# Altium schematic sheet Schematic.SchDoc(Schematic)
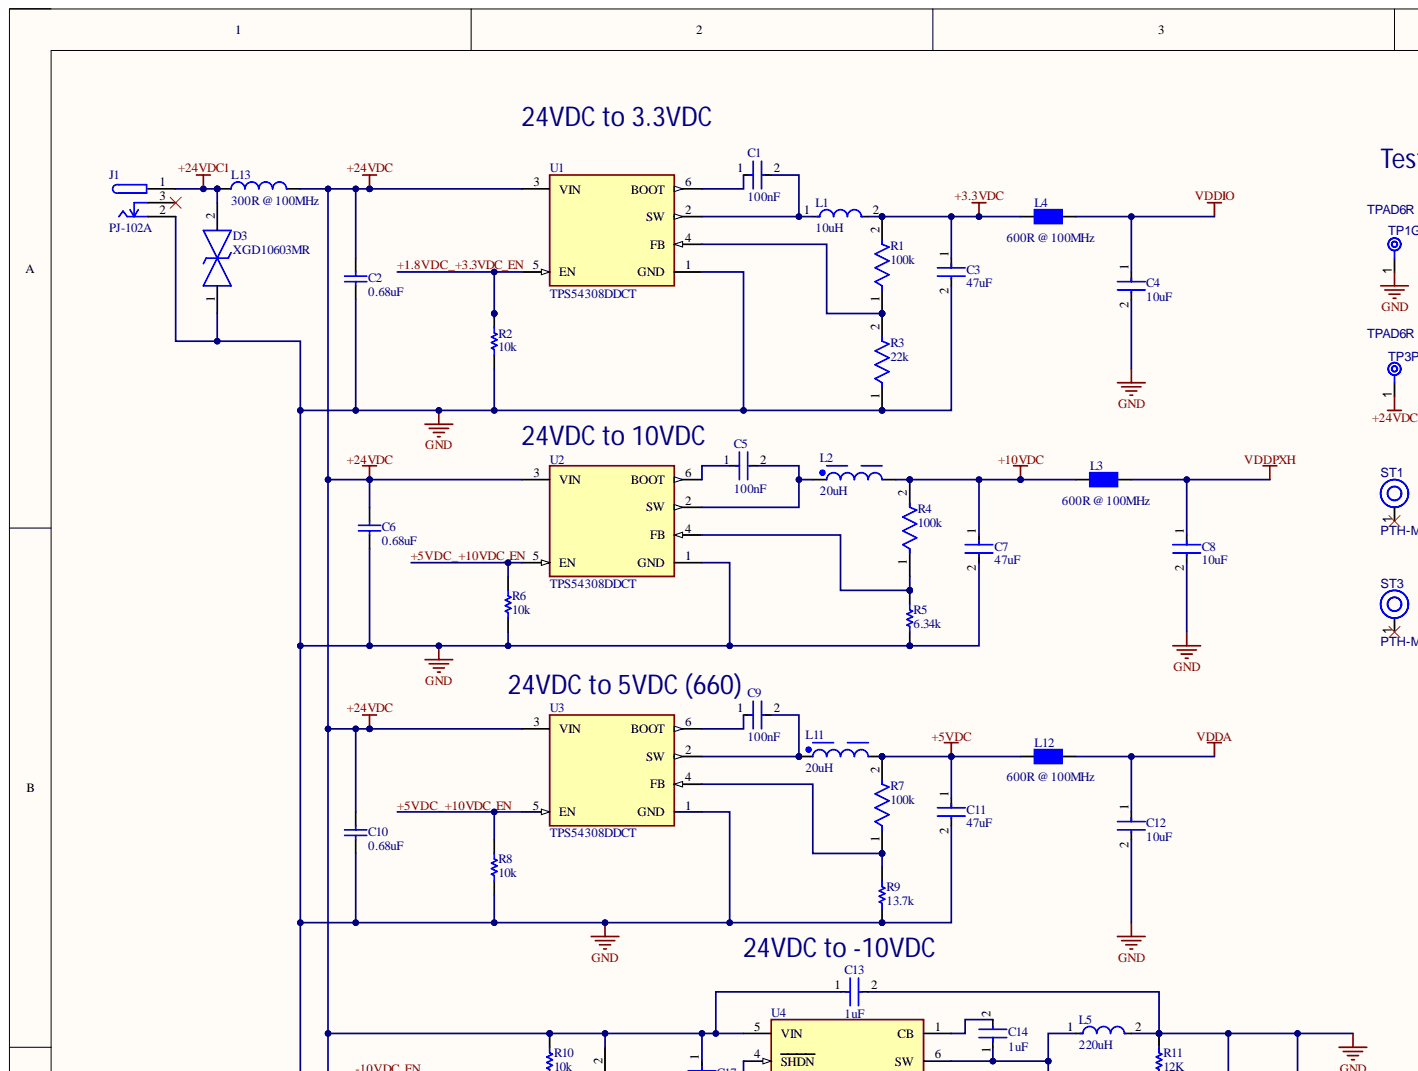
[diagram p0: Schematic.SchDoc, top left]
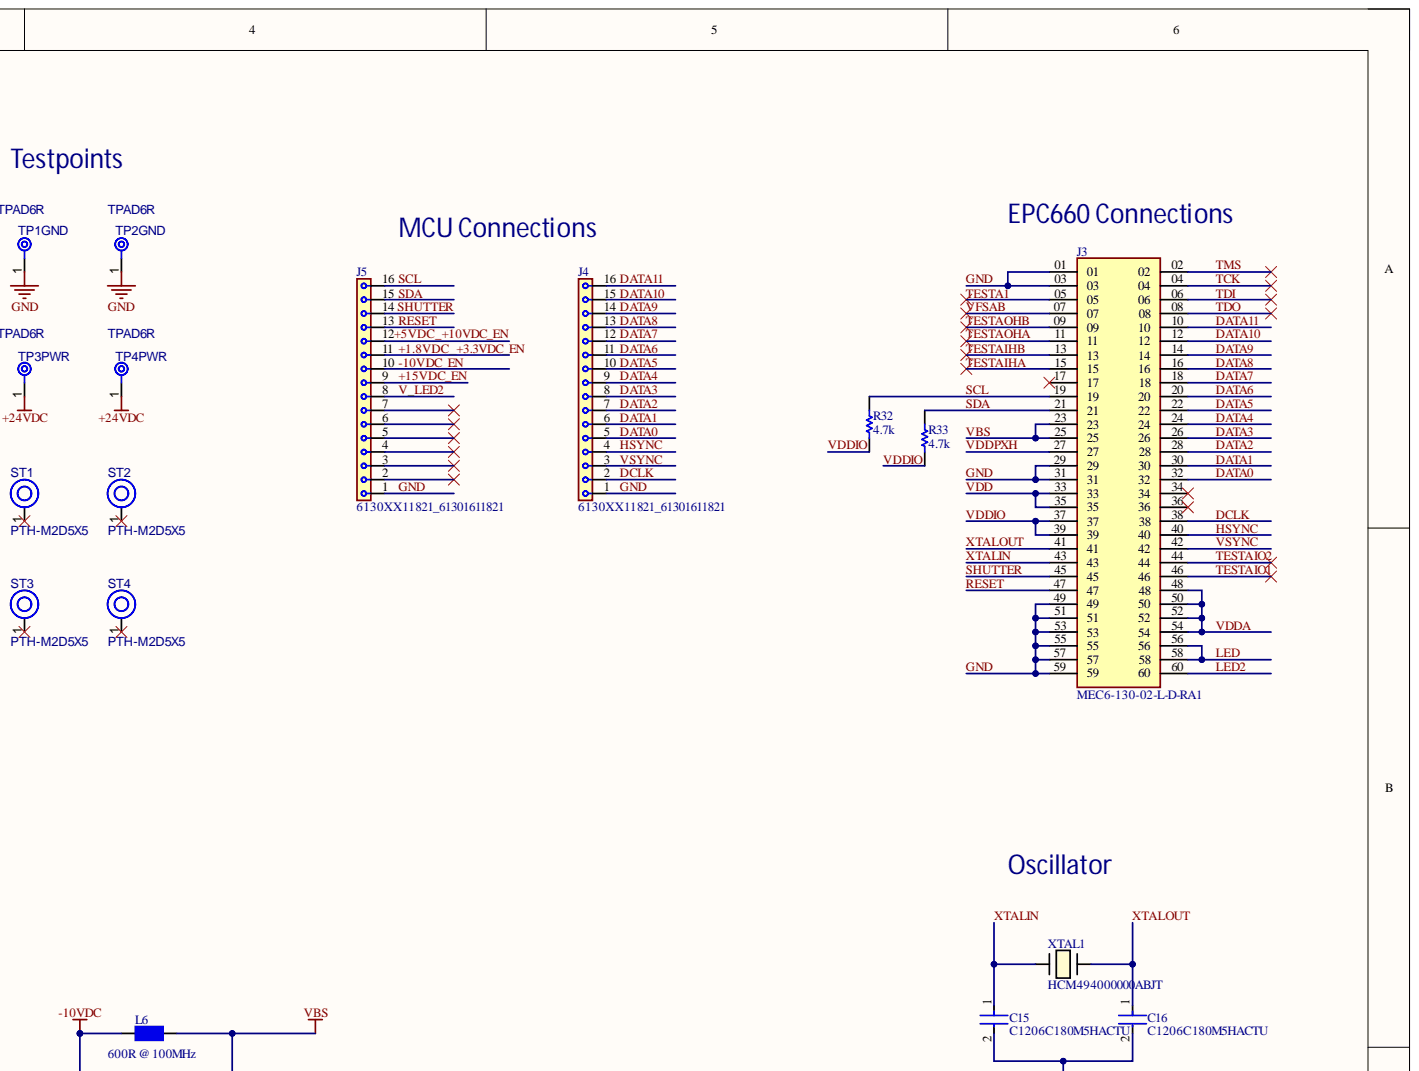
[diagram p1: Schematic.SchDoc, top right]
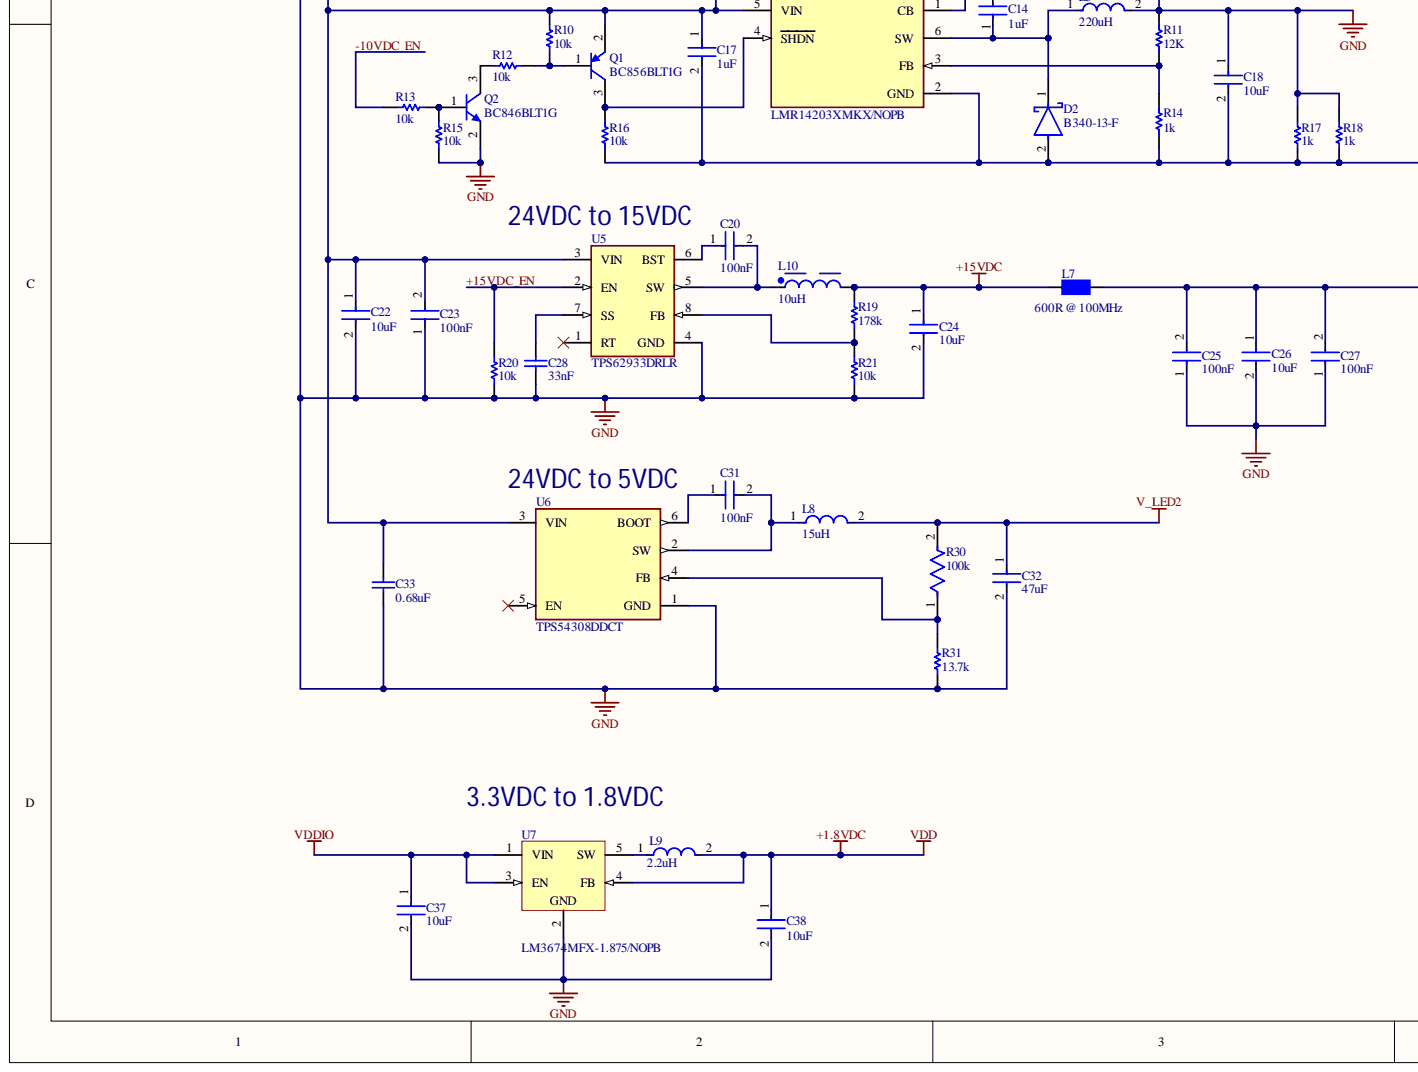
[diagram p2: Schematic.SchDoc, bottom left]
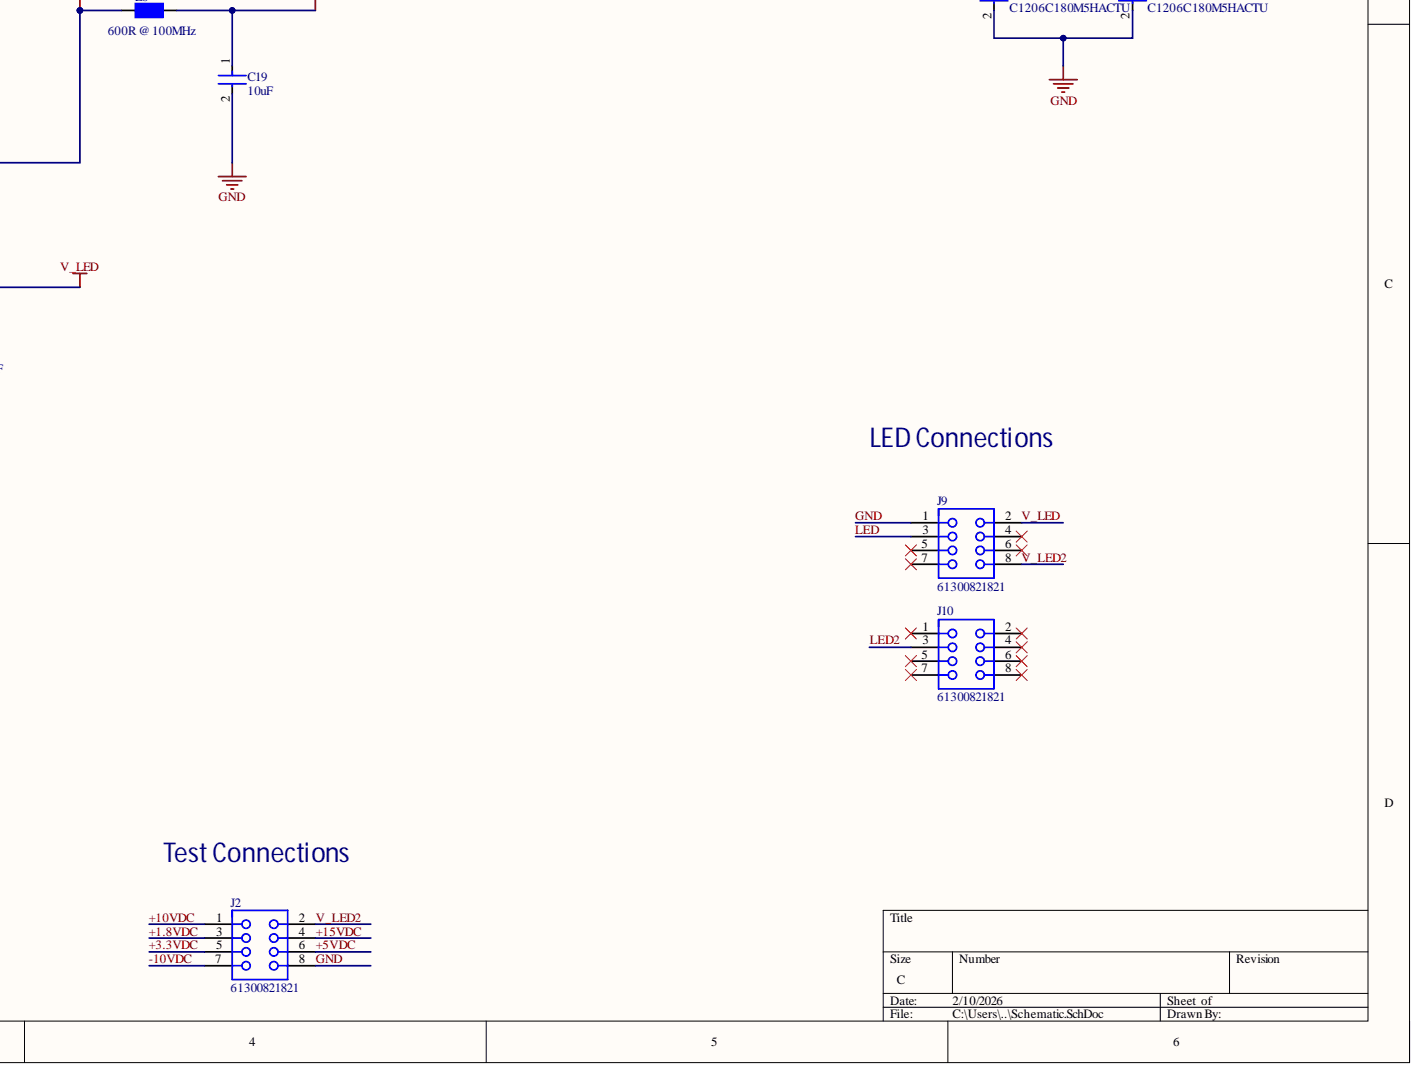
[diagram p3: Schematic.SchDoc, bottom right]

## Parts
C1  100nF  [FP-C0805C-DN-MFG]
C2  0.68uF  [CAPC2013X145X50ML20T25]
C3  47uF  [FP-C3216-160-0_2-MFG]
C4  10uF  [FP-CL31B106KBHNNNE-MFG]
C5  100nF  [FP-C0805C-DN-MFG]
C6  0.68uF  [CAPC2013X145X50ML20T25]
C7  47uF  [FP-C3216-160-0_2-MFG]
C8  10uF  [FP-CL31B106KBHNNNE-MFG]
C9  100nF  [FP-C0805C-DN-MFG]
C10  0.68uF  [CAPC2013X145X50ML20T25]
C11  47uF  [FP-C3216-160-0_2-MFG]
C12  10uF  [FP-CL31B106KBHNNNE-MFG]
C13  1uF  [FP-0805-L_2_0_0_1-W_1_25-MFG]
C14  1uF  [FP-0805-L_2_0_0_1-W_1_25-MFG]
C15  C1206C180M5HACTU  [FP-C1206C-EB-MFG]
C16  C1206C180M5HACTU  [FP-C1206C-EB-MFG]
C17  1uF  [FP-0805-L_2_0_0_1-W_1_25-MFG]
C18  10uF  [FP-CL31B106KBHNNNE-MFG]
C19  10uF  [FP-CL31B106KBHNNNE-MFG]
C20  100nF  [FP-C0805C-DN-MFG]
C22  10uF  [FP-CL31B106KBHNNNE-MFG]
C23  100nF  [FP-C0805C-DN-MFG]
C24  10uF  [FP-CL31B106KBHNNNE-MFG]
C25  100nF  [FP-C0805C-DN-MFG]
C26  10uF  [FP-CL31B106KBHNNNE-MFG]
C27  100nF  [FP-C0805C-DN-MFG]
C28  33nF  [CAPC2013X94X50NL20T25]
C31  100nF  [FP-C0805C-DN-MFG]
C32  47uF  [FP-C3216-160-0_2-MFG]
C33  0.68uF  [CAPC2013X145X50ML20T25]
C37  10uF  [FP-CL31B106KBHNNNE-MFG]
C38  10uF  [FP-CL31B106KBHNNNE-MFG]
D2  B340-13-F  [FP-SMC-MFG]
D3  XGD10603MR  [DIONC1708X51N]
J1  PJ-102A  [FP-PJ-102A-MFG]
J2  61300821821  [61300821821]
J3  MEC6-130-02-L-D-RA1  [SAMTEC_MEC6-130-02-L-D-RA1]
J4  6130XX11821_61301611821  [61301611821]
J5  6130XX11821_61301611821  [61301611821]
J9  61300821821  [61300821821]
J10  61300821821  [61300821821]
L1  10uH  [FP-LB3225-2_5-0_2-IPC_B]
L2  20uH  [WE-TI_8095]
L3  600R @ 100MHz  [BEADC2012X105N]
L4  600R @ 100MHz  [BEADC2012X105N]
L5  220uH  [FP-NR10050-MFG]
L6  600R @ 100MHz  [BEADC2012X105N]
L7  600R @ 100MHz  [BEADC2012X105N]
L8  15uH  [FP-CB3225-IPC_C]
L9  2.2uH  [FP-LQM2HPN_G0-IPC_C]
L10  10uH  [IND_SRR1208-100ML]
L11  20uH  [WE-TI_8095]
L12  600R @ 100MHz  [BEADC2012X105N]
L13  300R @ 100MHz  [WE-CBF_0805_L2W1.2H0.9_HC]
Q1  BC856BLT1G  [ONSC-SOT-23-3-318-08_V]
Q2  BC846BLT1G  [ONSC-SOT-23-3-318-08_V]
R1  100k  [FP-RC0805-0_6-IPC_C]
R2  10k  [RESC2013X60X35ML10T20]
R3  22k  [FP-RC0805-0_6-IPC_A]
R4  100k  [FP-RC0805-0_6-IPC_C]
R5  6.34k  [RESC2013X60X35LL10T20]
R6  10k  [RESC2013X60X35ML10T20]
R7  100k  [FP-RC0805-0_6-IPC_C]
R8  10k  [RESC2013X60X35ML10T20]
R9  13.7k  [RESC3116X65X40NL10T20]
R10  10k  [RESC2013X60X35ML10T20]
R11  12K  [RESC0805(2012)_L]
R12  10k  [RESC2013X60X35ML10T20]
R13  10k  [RESC2013X60X35ML10T20]
R14  1k  [RESC2013X50X30NL20T20]
R15  10k  [RESC2013X60X35ML10T20]
R16  10k  [RESC2013X60X35ML10T20]
R17  1k  [RESC2013X50X30NL20T20]
R18  1k  [RESC2013X50X30NL20T20]
R19  178k  [RESC2013X60X35LL10T20]
R20  10k  [RESC2013X60X35ML10T20]
R21  10k  [RESC2013X60X35ML10T20]
R30  100k  [FP-RC0805-0_6-IPC_C]
R31  13.7k  [RESC3116X65X40NL10T20]
R32  4.7k  [YAGO-RC0805_M]
R33  4.7k  [YAGO-RC0805_M]
ST1  PTH-M2D5X5  [PTH-M2D5X5]  (no BOM)
ST2  PTH-M2D5X5  [PTH-M2D5X5]  (no BOM)
ST3  PTH-M2D5X5  [PTH-M2D5X5]  (no BOM)
ST4  PTH-M2D5X5  [PTH-M2D5X5]  (no BOM)
TP1GND  TPAD6R  [TP06R]  (no BOM)
TP2GND  TPAD6R  [TP06R]  (no BOM)
TP3PWR  TPAD6R  [TP06R]  (no BOM)
TP4PWR  TPAD6R  [TP06R]  (no BOM)
U1  TPS54308DDCT  [FP-DDC0006A-IPC_A]
U2  TPS54308DDCT  [FP-DDC0006A-IPC_A]
U3  TPS54308DDCT  [FP-DDC0006A-IPC_A]
U4  LMR14203XMKX/NOPB  [FP-DDC0006A-IPC_B]
U5  TPS62933DRLR  [SOTFL50P160X60-8N]
U6  TPS54308DDCT  [FP-DDC0006A-IPC_A]
U7  LM3674MFX-1.875/NOPB  [MF05A_M]
XTAL1  HCM494000000ABJT  [XTAL_HCM494_CIT]

## Nets
+24VDC: C2.2 C6.2 C10.2 C13.1 C17.1 C22.1 C23.2 C33.2 L13.2 Q1.2 R10.2 TP3PWR.1 TP4PWR.1 U1.3(VIN) U2.3(VIN) U3.3(VIN) U4.5(VIN) U5.3(VIN) U6.3(VIN)
+24VDC1: D3.2 J1.1 L13.1
+1.8VDC: C38.1 J2.3 J3.33 J3.35 L9.2 U7.4(FB)
+1.8VDC_+3.3VDC_EN: J5.11 R2.2 U1.5(EN)
+3.3VDC: C3.1 J2.5 L1.2 L4.1 R1.2
+5VDC: C11.1 J2.6 L11.2 L12.1 R7.2
+5VDC_+10VDC_EN: J5.12 R6.2 R8.2 U2.5(EN) U3.5(EN)
+10VDC: C7.1 J2.1 L2.2 L3.1 R4.2
+15VDC: C24.1 J2.4 L7.1 L10.2 R19.2
+15VDC_EN: J5.9 R20.2 U5.2(EN)
-10VDC: C17.2 C18.2 D2.2 J2.7 L6.1 R14.1 R16.1 R17.1 R18.1 U4.2(GND)
-10VDC_EN: J5.10 R13.2
DATA0: J3.32 J4.5
DATA1: J3.30 J4.6
DATA2: J3.28 J4.7
DATA3: J3.26 J4.8
DATA4: J3.24 J4.9
DATA5: J3.22 J4.10
DATA6: J3.20 J4.11
DATA7: J3.18 J4.12
DATA8: J3.16 J4.13
DATA9: J3.14 J4.14
DATA10: J3.12 J4.15
DATA11: J3.10 J4.16
DCLK: J3.38 J4.2
GND: C2.1 C3.2 C4.2 C6.1 C7.2 C8.2 C10.1 C11.2 C12.2 C13.2 C15.2 C16.2 C18.1 C19.2 C22.2 C23.1 C24.2 C25.1 C26.2 C27.1 C28.1 C32.2 C33.1 C37.2 C38.2 D3.1 J1.2 J2.8 J3.01 J3.03 J3.29 J3.31 J3.49 J3.51 J3.53 J3.55 J3.57 J3.59 J4.1 J5.1 J9.1 L5.2 Q2.2 R2.1 R3.1 R5.1 R6.1 R8.1 R9.2 R11.2 R15.1 R17.2 R18.2 R20.1 R21.1 R31.2 TP1GND.1 TP2GND.1 U1.1(GND) U2.1(GND) U3.1(GND) U5.4(GND) U6.1(GND) U7.2
HSYNC: J3.40 J4.4
LED: J3.56 J3.58 J9.3
LED2: J3.60 J10.3
RESET: J3.47 J5.13
SCL: J3.19 J5.16 R32.2
SDA: J3.21 J5.15 R33.2
SHUTTER: J3.45 J5.14
TCK: J3.04
TDI: J3.06
TDO: J3.08
TESTA1: J3.05
TESTAIHA: J3.15
TESTAIHB: J3.13
TESTAIO1: J3.46
TESTAIO2: J3.44
TESTAOHA: J3.11
TESTAOHB: J3.09
TMS: J3.02
V_LED: C25.2 C26.1 C27.2 J9.2 L7.2
V_LED2: C32.1 J2.2 J5.8 J9.8 L8.2 R30.2
VBS: C19.1 J3.23 J3.25 L6.2
VDDA: C12.1 J3.48 J3.50 J3.52 J3.54 L12.2
VDDIO: C4.1 C37.1 J3.37 J3.39 L4.2 R32.1 R33.1 U7.1(VIN) U7.3(EN)
VDDPXH: C8.1 J3.27 L3.2
VFSAB: J3.07
VSYNC: J3.42 J4.3
XTALIN: C15.1 J3.43 XTAL1.1
XTALOUT: C16.1 J3.41 XTAL1.2
NetC1_1: C1.1 U1.6(BOOT)
NetC1_2: C1.2 L1.1 U1.2(SW)
NetC5_1: C5.1 U2.6(BOOT)
NetC5_2: C5.2 L2.1 U2.2(SW)
NetC9_1: C9.1 U3.6(BOOT)
NetC9_2: C9.2 L11.1 U3.2(SW)
NetC14_1: C14.1 D2.1 L5.1 U4.6(SW)
NetC14_2: C14.2 U4.1(CB)
NetC20_1: C20.1 U5.6(BST)
NetC20_2: C20.2 L10.1 U5.5(SW)
NetC28_2: C28.2 U5.7(SS)
NetC31_1: C31.1 U6.6(BOOT)
NetC31_2: C31.2 L8.1 U6.2(SW)
NetL9_1: L9.1 U7.5(SW)
NetQ1_1: Q1.1 R10.1 R12.1
NetQ1_3: Q1.3 R16.2 U4.4(SHDN)
NetQ2_1: Q2.1 R13.1 R15.2
NetQ2_3: Q2.3 R12.2
NetR1_1: R1.1 R3.2 U1.4(FB)
NetR4_1: R4.1 R5.2 U2.4(FB)
NetR7_1: R7.1 R9.1 U3.4(FB)
NetR11_1: R11.1 R14.2 U4.3(FB)
NetR19_1: R19.1 R21.2 U5.8(FB)
NetR30_1: R30.1 R31.1 U6.4(FB)

Not connected: J1 3; J3 17 34 36; J5 2 3 4 5 6 7; J9 4 5 6 7; J10 1 2 4 5 6 7 8; ST1 1; ST2 1; ST3 1; ST4 1; U5 1(RT); U6 5(EN)

## Library data of the parts
C1 C5 C9 C20 C23 C25 C27 C31 = CMP-1036-04423-3: CAP CER 0.1UF 50V X7R 0805; Manufacturer: KEMET; Manufacturer Part Number: C0805C104M5RACTU; Capacitance: 0.10 μF; Material: Ceramic; Temperature Coefficient: 30ppm/°C; Tolerance: 0.2; Value: 0.10 μF; Automotive: No; Category: Cap; Component Kind: Standard; ComponentLink2Description: Datasheet; ComponentLink2URL: https://content.kemet.com/datasheets/KEM_C1003_C0G_SMD.pdf; Device Class L1: Passive Components; Device Class L2: Capacitors; Device Class L3: Ceramic Capacitors; Digikey Description: CAP CER 0.1UF 50V X7R 0805; Height: 0.88mm; Ipc Land Pattern Name: CAPC200125X78; Lead Free: Yes; Library Name: Altium Content Vault; Mouser Description: Multilayer Ceramic Capacitors MLCC - SMD/SMT 50volts 0.1uF X7R 20%; Octopart: https://octopart.com/c0805c104m5ractu-kemet-129379; Package: C0805C-DN; RoHS: TRUE; Temperature: -55°C to +125°C; Temperature Characteristic: X7R; Temperature Range High: +125°C; Temperature Range Low: -55°C; Voltage Rating: 50 V
C2 C6 C10 C33 = CMP-1036-04712-2: CAP CER 0.68UF 50V X7R 0805; Manufacturer: Kemet; Part Number: C0805C684K5RACTU; Component Kind: Standard; Library Name: Altium Content Vault
C3 C7 C11 C32 = CMP-2000-05397-3: Multilayer Ceramic Capacitors 47uF ±20% 25V X5R SMD 1206; Capacitance: 47uF; Case Code (Imperial): 1206; Case Code (Metric): 3216; Case/Package: 1206; Component Kind: Standard; Depth: 1.6mm; Dielectric: X5R; Dielectric Material: Ceramic; Height: 1.6mm; Lead Free: Lead Free; Length: 0.126inch; Library Name: Altium Content Vault; Max Operating Temperature: 85°C; Min Operating Temperature: -55°C; Mount: Surface Mount; Package Quantity: 1; Packaging: Tape and Reel; REACH SVHC: No SVHC; Resistor Type: Ceramic; RoHS Compliant: Yes; Series: C; Termination: SMD/SMT; Thickness: 0.071inch; Tolerance: 20%; Voltage: 25V; Voltage Rating: 25V; Voltage Rating (DC): 25V; Weight: 0.000571oz; Width: 0.063inch
C4 C8 C12 C18 C19 C22 C24 C26 C37 C38 = CMP-2000-07530-2: CAP CER 10UF 50V X7R 1206; Manufacturer: Samsung; Manufacturer Part Number: CL31B106KBHNNNE; Material: Ceramic; Temperature Coefficient: 15%; Tolerance: 10%; Automotive: No; Capacitance: 10uF; Category: Cap; Component Kind: Standard; ComponentLink2Description: Datasheet; ComponentLink2URL: http://www.samsungsem.com/global/front/downloadcms.do?path=%2F%2Fglobal%2F%2Fsupport%2F%2Fproduct-search%2F%2Fmlcc%2F%2F__icsFiles%2F%2Fafieldfile%2F%2F2019%2F%2F06%2F%2F18&fileName=CL31B106KBHNNNE-19.pdf; Device Class L1: Passive Components; Device Class L2: Capacitors; Device Class L3: Ceramic Capacitors; Digikey Description: CAP CER 10UF 50V X7R 1206; Height: 1.8mm; Lead Free: Yes; Library Name: Altium Content Vault; Octopart: https://octopart.com/cl31b106kbhnnne-samsung-30507377; Package: CL31B106KBHNNNE; RoHS: TRUE; Temperature: -55°C to +125°C; Temperature Characteristic: X7R; Temperature Range High: +125°C; Temperature Range Low: -55°C; Value: 10uF; Voltage Rating: 50V
C13 C14 C17 = CMP-2000-07356-3: Multilayer Ceramic Capacitor 1 uF 50V X7R 10% SMD 0805 T/R; Capacitance: 1uF; Case Code (Imperial): 0805; Case Code (Metric): 2012; Case/Package: 0805; Component Kind: Standard; Construction: Flat; Depth: 1.25mm; Dielectric: X7R; Halogen Free: Halogen Free; Height: 1.25mm; Length: 2mm; Library Name: Altium Content Vault; Max Operating Temperature: 125°C; Min Operating Temperature: -55°C; Mount: Surface Mount; Package Quantity: 1; Packaging: Tape and Reel; RoHS Compliant: Yes; Series: M; Termination: SMD/SMT; Thickness: 0.053inch; Tolerance: 10%; Voltage: 50V; Voltage Rating: 50V; Voltage Rating (DC): 50V; Width: 0.049inch
C15 C16 = CMP-03020-018739-1: C1206 18 pF X8R 20.00% 50 V; Manufacturer: KEMET; Automotive Grade: Grade 0; Capacitance: 18 pF; Material: Ceramic; Temperature Coefficient: 15%; Tolerance: 0.2; Value: 18 pF; Voltage Rating: 50 V; Automotive: Yes; Category: Cap; Component Kind: Standard; ComponentLink2Description: Datasheet; ComponentLink2URL: https://content.kemet.com/datasheets/KEM_C1007_X8R_ULTRA_150C_SMD.pdf; Device Class L1: Passive Components; Device Class L2: Capacitors; Device Class L3: Ceramic Capacitors; Height: 0.88mm; Ipc Land Pattern Name: CAPC320160X78; Lead Free: Yes; Library Name: Altium Content Vault; Manufacturer Part Number: C1206C180M5HACTU; Octopart: https://octopart.com/c1206c180m5hactu-kemet-88728204; Package: C1206C-EB; RoHS: TRUE; Temperature: -55°C to +150°C; Temperature Characteristic: X8R; Temperature Range High: +150°C; Temperature Range Low: -55°C
C28 = CMP-2007-04242-1: 0805 33 nF 25 V ±10 % Tolerance X7R Surface Mount Multilayer Ceramic Capacitor; Manufacturer: AVX Interconnect / Elco; Part Number: 08053C333KAT2A; Component Kind: Standard; Library Name: Altium Content Vault
D2 = CMP-12790-000049-1: DIODE SCHOTTKY 40V 3A SMC; Manufacturer: Diodes Inc.; Capacitance: 200pF; Max Junction Temp: +150°C; Max Surge Forward Current: 100A; Peak Reverse Current: 0.1mA; Standoff Height: 0.1mm; Value: 200pF; Automotive: No; Category: Diode; Component Kind: Standard; ComponentLink2Description: Datasheet; ComponentLink2URL: https://www.diodes.com/assets/Datasheets/ds30923.pdf; Device Class L1: Discrete Semiconductors; Device Class L2: Diodes; Device Class L3: Schottky Diodes; Digikey Description: DIODE SCHOTTKY 40V 3A SMC; Footprint Url: https://www.diodes.com/assets/Package-Files/SMC.pdf; Forward Current: 3A; Height: 2.5mm; Lead Free: Yes; Library Name: Altium Content Vault; Manufacturer Part Number: B340-13-F; Max Repetitive Reverse Voltage: 40V; Mouser Description: Diode Schottky 40V 3A 2-Pin SMC T/R Diode Schottky 40V 3A 2-Pin SMC T/R; Octopart: https://octopart.com/b340-13-f-diodes+inc.-325814; Package: SMC; Reverse Voltage: 40V; RoHS: TRUE; Temperature: -55°C to +150°C; Temperature Range High: +150°C; Temperature Range Low: -55°C
D3 = XGD10603MR: ESD Suppressors / TVS Diodes 0603 32VDC .09pF; Manufacturer_Name: LITTELFUSE; Geometry.Height: 0.51mm; Component Kind: Standard; Datasheet Link: https://www.littelfuse.com/~/media/electronics/datasheets/polymer_esd_suppressors/littelfuse_xtremeguard_xgd_datasheet.pdf.pdf; Manufacturer_Part_Number: XGD10603MR; Mouser Part Number: 576-XGD10603MR; Mouser Price/Stock: https://www.mouser.co.uk/ProductDetail/Littelfuse/XGD10603MR?qs=4tuKoHGzn12MEovLe89CfA%3D%3D; Supplier 1: Newark; Supplier Part Number 1: XGD10603MR; Library Name: TVSDIODE.SchLib
J1 = CMP-12637-106234071-1: PJ-102A 2.0 x 6.5 mm, 2.5 A, Horizontal, Through Hole, Tapered Pins, Dc Power Jack Connector; Current Rating: 2.5A; Gender: Male; Inside Diameter: 2mm; Insulation Resistance: 50MΩ; Number of Conductors: 2; Number of Contacts: 3; Outside Diameter: 6.5mm; Shielding: No; Voltage Rating: 24V; Color: Black; Component Kind: Standard; Contact Gender: Male; Contact Material: Copper, Nickel, Tin; Contact Plating: Nickel; Diameter: 2.1mm; Flammability Rating: UL94 V-0; Height: 11.2mm; Housing Color: Black; Housing Material: Thermoplastic; Lead Free: Lead Free; Library Name: Altium Content Vault; Lifecycle Status: Production; Max Operating Temperature: 85°C; Min Operating Temperature: -25°C; Mount: Through Hole; NexarPartId: 106234071; Orientation: Horizontal; Packaging: Bulk; RoHS: Compliant; Schedule B: 8536695050, 8536695050; Termination: Through Hole; Voltage Rating (DC): 24V
J2 J9 J10 = CMP-1502-01329-3: NexarPartId: 61924725; Contact Resistance: 20mΩ; Current Rating: 3A; Gender: Female; Operating Supply Voltage: 250V; Component Kind: Standard; Lead Pitch: 2.54mm; Library Name: Altium Content Vault; Number of Pins: 8; Orientation: Vertical; RoHS: Non-Compliant
J4 J5 = 6130XX11821_61301611821: MF: {'manufacturer': u'W\xfcrth Elektronik'}; Availability: Unavailable; Component Kind: Standard; Library Name: 6130XX11821_61301611821.SchLib; MP: 61301611821; Package: None; Price: None
L1 = CMP-14484-000809-1: SMD Inductors 10uH ±10% 0.54A 0.1729Ω 1210; Core Material: Ferrite; Current Rating: 540mA; DC Resistance (DCR): 133mR; Resistance: 0.133R; Self Resonant Frequency: 23MHz; Shielding: Unshielded; Test Frequency: 100kHz; Case Code (Imperial): 1210; Case Code (Metric): 3225; Case/Package: 1210; Component Kind: Standard; Depth: 2.7mm; Halogen Free: Halogen Free; Height: 2.5mm; Height - Seated (Max): 0.106inch; Inductance: 10uH; Inductance Tolerance: 10%; Lead Pitch: 2.7mm; Length: 0.126inch; Library Name: Altium Content Vault; Max DC Current: 540mA; Max Operating Temperature: 105°C; Min Operating Temperature: -40°C; Mount: Surface Mount; Number of Pins: 2; Package Quantity: 1000; Packaging: Tape and Reel; Radiation Hardening: No; Resistor Type: Wirewound; RoHS Compliant: Yes; Series: LB; Termination: SMD/SMT; Tolerance: 10%; Width: 0.098inch
L2 L11 = CMP-03913-120919850-2: 7447720200 Power Inductor, 20Uh, Unshielded, 3.1A Rohs Compliant: Yes; DC Resistance (DCR): 44mΩ; Inductance: 20µH; Voltage Rating: 80V; Ambient Temperature Range High: 85°C; Ambient Temperature Range Low: -40°C; Component Kind: Standard; Current Rating: 3.1A; Height: 9.5mm; Length: 7.8mm; Library Name: Altium Content Vault; Max Operating Temperature: 125°C; Min Operating Temperature: -40°C; NexarPartId: 120919850; RoHS: Compliant; Width: 7.8mm
L3 L4 L6 L7 L12 = BLM21PG601SN1D: Ferrite Beads Multi-Layer 600Ohm 25% 100MHz 1.4A 0.14Ohm DCR 0805; Manufacturer: Murata; Impedance: 600Ω; Number of Circuits: 1; Value: 140mΩ; Case/Package: 0805; Category: Ferrite Beads and Chips; Component Kind: Standard; ComponentLink1Description: https://datasheet.octopart.com/BLM21PG601SN1D-Murata-datasheet-37129980.pdf; ComponentLink1URL: https://datasheet.octopart.com/BLM21PG601SN1D-Murata-datasheet-37129980.pdf; ComponentLink2Description: http://sigma.octopart.com:80/reach_statement/Murata-company-16.pdf?src-supplier=Compliance+Statements; ComponentLink2URL: http://sigma.octopart.com:80/reach_statement/Murata-company-16.pdf?src-supplier=Compliance+Statements; Length: 2mm; Library Name: Schlib2.SchLib; Lifecycle Status: Production; Manufacturer Part Number: BLM21PG601SN1D; Pricing: 1=0.11, 10=0.078, 25=0.0676, 50=0.0608, 100=0.0545, 250=0.04692, 500=0.04166, 1000=0.03682 (USD); REACH SVHC: Yes; RoHS: Compliant; Stock: 1066; Supplier: DigiKey; Supplier Part Number: 490-BLM21PG601SN1DCT-ND; Width: 1.25mm
L5 = CMP-14484-000400-1: Inductor Power Shielded Wirewound 220uH 20% 100KHz Ferrite 0.8A 0.585Ω DCR T/R; Current Rating: 800mA; DC Resistance (DCR): 585mR; Max DC Current: 1A; Self Resonant Frequency: 3MHz; Case/Package: Nonstandard; Component Kind: Standard; Core Material: Ferrite; Depth: 10.3mm; Halogen Free: Halogen Free; Height: 5mm; Height - Seated (Max): 0.197inch; Inductance: 220uH; Inductance Tolerance: 20%; Inductor Type: POWER; Lead Free: Lead Free; Length: 0.394inch; Library Name: Altium Content Vault; Max Operating Temperature: 105°C; Min Operating Temperature: -25°C; Mount: Surface Mount; Number of Pins: 2; Package Quantity: 500; Packaging: Tape and Reel; Radiation Hardening: No; REACH SVHC: No SVHC; Resistor Type: Wirewound; RMS Current (Irms): 800mA; RoHS Compliant: Yes; Saturation Current: 1A; Series: NR; Shielding: Shielded; Termination: SMD/SMT; Test Frequency: 100kHz; Tolerance: 20%; Width: 9.8mm
L8 = CMP-14484-000168-1: SMD Inductors 15uH ±10% 0.85A 0.2535Ω 1210; Core Material: Ferrite; Current Rating: 850mA; DC Resistance (DCR): 253.5mR; Saturation Current: 730mA; Self Resonant Frequency: 20MHz; Shielding: Unshielded; Test Frequency: 100kHz; Case Code (Imperial): 1210; Case Code (Metric): 3225; Case/Package: 1210; Component Kind: Standard; Depth: 2.7mm; Halogen Free: Halogen Free; Height: 2.5mm; Height - Seated (Max): 0.106inch; Inductance: 15uH; Inductance Tolerance: 10%; Length: 0.126inch; Library Name: Altium Content Vault; Max DC Current: 850mA; Max Operating Temperature: 105°C; Min Operating Temperature: -40°C; Mount: Surface Mount; Package Quantity: 1000; Packaging: Tape and Reel; Radiation Hardening: No; Resistor Type: Wirewound; RoHS Compliant: Yes; Series: CB; Termination: SMD/SMT; Tolerance: 10%; Width: 0.098inch
L9 = CMP-1662-00028-2: Multilayer Type Inductor for Power Lines, 1008 (2520), 2.2μH, 20%, 0.1Ω, 1.3A; Core Material: Ferrite; DC Resistance (DCR): 100mR; Self Resonant Frequency: 40MHz; Shielding: Shielded; Test Frequency: 1MHz; Case Code (Imperial): 1008; Case Code (Metric): 2520; Case/Package: 1008; Component Kind: Standard; Current Rating: 1.3A; DC Current: 1.3A; Depth: 2.2mm; Height: 1.2mm; Height - Seated (Max): 0.039inch; Inductance: 2.2uH; Inductance Tolerance: 20%; Lead Free: Lead Free; Length: 2.5mm; Library Name: Altium Content Vault; Max DC Current: 1.3A; Max Operating Temperature: 125°C; Military Standard: Not; Min Operating Temperature: -55°C; Mount: Surface Mount; Package Quantity: 3000; Packaging: Tape and Reel; Radiation Hardening: No; REACH SVHC: No SVHC; Series: LQM2HP_G0; Termination: SMD/SMT; Tolerance: 20%; Width: 2mm
L10 = SRR1208-100ML(10uH): Inductor Power Shielded Wirewound 10uH 20% 1KHz 20Q-Factor Ferrite 5A 0.021Ohm DCR T/R; Manufacturer: Bourns; DC Resistance (DCR): 21mΩ; Frequency: 15MHz; Material: Steel; Number of Circuits: 1; Q Factor: 20; Saturation Current: 6.7A; Shielding: Yes; Value: 10µH; Case/Package: 5050; Category: Fixed Inductors; China RoHS: Compliant; Component Kind: Standard; ComponentLink1Description: https://datasheet.octopart.com:443/SRR1208-100ML-Bourns-datasheet-154729978.pdf?src-supplier=Newark; ComponentLink1URL: https://datasheet.octopart.com:443/SRR1208-100ML-Bourns-datasheet-154729978.pdf?src-supplier=Newark; ComponentLink2Description: https://datasheet.octopart.com:443/SRR1208-100ML-Bourns-datasheet-68307255.pdf?src-supplier=Newark; ComponentLink2URL: https://datasheet.octopart.com:443/SRR1208-100ML-Bourns-datasheet-68307255.pdf?src-supplier=Newark; ComponentLink3Description: https://datasheet.octopart.com:443/SRR1208-100ML-Bourns-datasheet-99355712.pdf?src-supplier=Newark; ComponentLink3URL: https://datasheet.octopart.com:443/SRR1208-100ML-Bourns-datasheet-99355712.pdf?src-supplier=Newark; ComponentLink4Description: https://datasheet.octopart.com:443/SRR1208-100ML-Bourns-datasheet-5459063.pdf?src-supplier=Newark; ComponentLink4URL: https://datasheet.octopart.com:443/SRR1208-100ML-Bourns-datasheet-5459063.pdf?src-supplier=Newark; ComponentLink5Description: https://datasheet.octopart.com:443/SRR1208-100ML-Bourns-datasheet-11548354.pdf?src-supplier=Farnell; ComponentLink5URL: https://datasheet.octopart.com:443/SRR1208-100ML-Bourns-datasheet-11548354.pdf?src-supplier=Farnell; ComponentLink6Description: https://datasheet.octopart.com:443/SRR1208-100ML-Bourns-datasheet-62333742.pdf?src-supplier=Farnell; ComponentLink6URL: https://datasheet.octopart.com:443/SRR1208-100ML-Bourns-datasheet-62333742.pdf?src-supplier=Farnell; ComponentLink7Description: https://datasheet.octopart.com:443/SRR1208-100ML-Bourns-datasheet-41338362.pdf?src-supplier=RS+(Formerly+Allied+Electronics); ComponentLink7URL: https://datasheet.octopart.com:443/SRR1208-100ML-Bourns-datasheet-41338362.pdf?src-supplier=RS+(Formerly+Allied+Electronics); ComponentLink8Description: https://datasheet.octopart.com:443/SRR1208-100ML-Bourns-datasheet-37486976.pdf?src-supplier=RS+(Formerly+Allied+Electronics); ComponentLink8URL: https://datasheet.octopart.com:443/SRR1208-100ML-Bourns-datasheet-37486976.pdf?src-supplier=RS+(Formerly+Allied+Electronics); ComponentLink9Description: https://datasheet.octopart.com:443/SRR1208-100ML-Bourns-datasheet-56155.pdf?src-supplier=RS+(Formerly+Allied+Electronics); ComponentLink9URL: https://datasheet.octopart.com:443/SRR1208-100ML-Bourns-datasheet-56155.pdf?src-supplier=RS+(Formerly+Allied+Electronics); ComponentLink10Description: http://sigma.octopart.com:80/conflict_mineral_statement/Bourns-company-36.pdf?src-supplier=Compliance+Statements; ComponentLink10URL: http://sigma.octopart.com:80/conflict_mineral_statement/Bourns-company-36.pdf?src-supplier=Compliance+Statements; Composition: Wirewound; Core Material: Ferrite; Current Rating: 5A; DC Current: 5A; Depth: 13mm; Height: 8.5mm; Height - Seated (Max): 8.509mm; Inductance Tolerance: 20%; Length: 12.7mm; Library Name: Schlib2.SchLib; Lifecycle Status: Production; Manufacturer Part Number: SRR1208-100ML; Max Current Rating: 5A; Max DC Current: 5A; Max Operating Temperature: 125°C; Military Standard: Not; Min Operating Temperature: -40°C; Mounting Technology: Surface Mount; Number of Terminals: 2; Pins: 2; Pricing: 1=0.9, 10=0.741, 100=0.609 (USD); REACH SVHC: Yes; RoHS: Compliant; Schedule B: 8504500000, 8504500000|8504500000, 8504500000|8504500000|8504500000, 8504500000|8504500000|8504500000|8504500000; Self Resonant Frequency: 15MHz; Stock: 7937; Supplier: DigiKey; Supplier Part Number: SRR1208-100MLCT-ND; Termination: SMD/SMT; Test Frequency: 1kHz; Tolerance: 20%; Width: 12.7mm
L13 = CMP-0220-00025-2: NexarPartId: 21041540; Current Rating: 3A; Impedance: 300Ω; Resistance: 50mΩ; Component Kind: Standard; Description: 300R @ 100MHz; Height: 900µm; Length: 2mm; Library Name: Altium Content Vault; Max Operating Temperature: 125°C; Min Operating Temperature: -55°C; Packaging: Digi-Reel®; RoHS: Compliant; Width: 1.2mm
Q1 = CMP-1048-00715-1: General Purpose Transistor, PNP Silicon, 3-Pin SOT-23, Pb-Free, Tape and Reel; Manufacturer: On Semiconductor; fT Min (MHz): 100; IC Continuous (A): -0.1; Component Kind: Standard; ComponentLink1Description: Manufacturer URL; ComponentLink1URL: http://www.onsemi.com/; ComponentLink2Description: Datasheet; ComponentLink2URL: http://www.onsemi.cn/pub_link/Collateral/BC856ALT1-D.PDF; ComponentLink3Description: Package Specification; ComponentLink3URL: http://www.onsemi.cn/pub_link/Collateral/318-08.PDF; DatasheetVersion: Rev. 13; Library Name: Altium Content Vault; Mounting Technology: Surface Mount; PackageDescription: 3-Pin Small Outline Transistor, Body 2.92 x 1.3 mm, Pitch 0.95 mm; PackageReference: SOT-23-3-318-08; PackageVersion: Rev. AP, 11/2009; Packing: Tape and Reel; PartNumber: BC856BLT1G; Polarity: PNP; RoHS: TRUE
Q2 = CMP-1048-00779-1: General Purpose Transistor, NPN Silicon, 3-Pin SOT-23, Pb-Free, Tape and Reel; Manufacturer: On Semiconductor; fT Min (MHz): 100; IC Continuous (A): 0.1; Component Kind: Standard; ComponentLink1Description: Manufacturer URL; ComponentLink1URL: http://www.onsemi.com/; ComponentLink2Description: Datasheet; ComponentLink2URL: http://www.onsemi.cn/pub_link/Collateral/BC846ALT1-D.PDF; ComponentLink3Description: Package Specification; ComponentLink3URL: http://www.onsemi.cn/pub_link/Collateral/318-08.PDF; DatasheetVersion: Rev. 11; Library Name: Altium Content Vault; Mounting Technology: Surface Mount; PackageDescription: 3-Pin Small Outline Transistor, Body 2.92 x 1.3 mm, Pitch 0.95 mm; PackageReference: SOT-23-3-318-08; PackageVersion: Rev. AP, 11/2009; Packing: Tape and Reel; PartNumber: BC846BLT1G; Polarity: NPN; RoHS: TRUE
R1 R4 R7 R30 = CMP-2100-03668-5: RES 100K OHM 1% 1/8W 0805; Max Power Dissipation: 125mW; Resistance: 100kR; Temperature Coefficient: 100ppm/°C; Voltage Rating: 150V; Case Code (Imperial): 0805; Case Code (Metric): 2012; Case/Package: 0805; Component Kind: Standard; Construction: Rectangular; Depth: 1.25mm; Features: Moisture Resistant; Height: 0.024inch; Lead Free: Lead Free; Lead Pitch: 0.4mm; Length: 0.079inch; Library Name: Altium Content Vault; Max Operating Temperature: 155°C; Military Standard: Not; Min Operating Temperature: -55°C; Number of Pins: 2; Number of Terminations: 2; Package Quantity: 1; Packaging: Tape and Reel; Power Rating: 125mW; Resistance Tolerance: 1%; Resistor Type: Thick Film; RoHS Compliant: Yes; Series: RC; Size Code: 0805; Technology: THICK FILM; Termination: SMD/SMT; Tolerance: 1%; Voltage Rating (DC): 150V; Width: 0.049inch
R2 R6 R8 R10 R12 R13 R15 R16 R20 R21 = CMP-2000-05025-1: Chip Resistor, 10 KOhm, +/- 5%, 0.125 W, -55 to 155 degC, 0805 (2012 Metric), RoHS, Tape and Reel; Manufacturer: Yageo; Power Rating: 250mW; Product Range: RCSeries; Resistance: 10kohm; Resistor Case Style: 0805[2012Metric]; Resistor Element Type: ThickFilm; SVHC: ToBeAdvised; Temperature Coefficient: ±100ppm/degC; Voltage Rating: 150V; Automotive Qualification Standard: -; Component Kind: Standard; Datasheet URL: http://www.yageo.ru/pdf/RC0805.pdf; Datasheet Version: REV. 2, 09/2004; Library Name: Altium Content Vault; Manufacturer URL: http://www.yageo.com/; MSL: MSL1-Unlimited; Package Description: 2-Pin Surface Mounted Device, Body 2 x 1.25 mm; Package Reference: 805; Packaging: CutTape; Resistance Tolerance: ±5%
R3 = CMP-03412-050976-1: RES 22K OHM 1% 1/8W 0805; Max Power Dissipation: 125mW; Resistance: 22kR; Temperature Coefficient: 100ppm/°C; Voltage Rating: 150V; Case Code (Imperial): 0805; Case Code (Metric): 2012; Case/Package: 0805; Component Kind: Standard; Construction: Rectangular; Depth: 1.25mm; Features: Moisture Resistant; Height: 0.024inch; Lead Free: Lead Free; Length: 2mm; Library Name: Altium Content Vault; Max Operating Temperature: 155°C; Military Standard: Not; Min Operating Temperature: -55°C; Number of Terminations: 2; Package Quantity: 1; Packaging: Tape and Reel; Power Rating: 125mW; Resistance Tolerance: 1%; Resistor Type: Thick Film; RoHS Compliant: Yes; Series: RC; Termination: SMD/SMT; Tolerance: 1%; Weight: 0.000194oz; Width: 0.049inch; Working Voltage: 150V
R5 = CMP-2001-01245-1: Manufacturer: Yageo; Part Number: RC0805FR-076K34L; Component Kind: Standard; Library Name: Altium Content Vault
R9 R31 = CMP-2003-03553-1: Manufacturer: Yageo; Part Number: RC1206FR-0713K7L; Component Kind: Standard; Library Name: Altium Content Vault
R11 = CMP-1013-00631-1: 12K 0.125W 1% 0805 (2012 Metric)  SMD; Case-EIA: 0805; Case-Metric: 2012; Value: 12000.00 Ohm; Component Kind: Standard; Library Name: Altium Content Vault; Power: 0.125 W; Technology: SMT; Tolerance: 1 %
R14 R17 R18 = CMP-1013-00510-2: RES SMD 1K OHM 1% 1/4W 0805; Manufacturer: Vishay Dale; Part Number: CRCW08051K00FKEA; Component Kind: Standard; Library Name: Altium Content Vault
R19 = CMP-2001-00940-1: Manufacturer: Yageo; Part Number: RC0805FR-07178KL; Component Kind: Standard; Library Name: Altium Content Vault
R32 R33 = CMP-1568-00001-1: General Puprose Chip Resistor, 4700 Ohm +/- 1%, 0.125 W, -55 to 155 degC, 2-Pin SMD, RoHS, Tape and Reel; PartNumber: RC0805FR-074K7L; Power: 0.125 W; Tolerance: +/- 1%; Component Kind: Standard; ComponentLink1Description: Manufacturer URL; ComponentLink1URL: http://www.yageo.com/NewPortal/_en/index.jsp; ComponentLink2Description: Datasheet; ComponentLink2URL: http://www.yageo.com/documents/recent/PYu-RC_Group_51_RoHS_L-3.pdf; DatasheetVersion: Rev. 3; Library Name: Altium Content Vault; Mounting Technology: Surface Mount; PackageDescription: 2-Pin Surface Mount Device, Body 2 x 1.25 mm; Packing: Tape and Reel; Resistance: 4700 Ohm; RoHS: TRUE; Temperature Max: 155 degC; Temperature Min: -55 degC
ST1-ST4 = CMP-00028-00004-2: M2 PTH (2.5mm), 5mm pad; Pins: 1; Library Name: Calvin2004
TP1GND TP2GND TP3PWR TP4PWR = CMP-00028-00002-2: 0.6mm round; Pins: 1; Library Name: Calvin2004
U1-U3 U6 = CMP-04918-000241-1: IC REG BUCK ADJ 3A TSOT23-6; Max Input Voltage: 28V; Max Output Voltage: 26V; Min Input Voltage: 4.5V; Min Output Voltage: 1V; Number of Outputs: 1; Output Configuration: Positive; Output Type: Adjustable; Switching Frequency: 350kHz; Synchronous Rectifier: Yes; Case/Package: SOT-23-6; Component Kind: Standard; Library Name: Altium Content Vault; Max Operating Temperature: 125°C; Min Operating Temperature: -40°C; Mount: Surface Mount; Output Current: 3A; Packaging: Tape and Reel; RoHS Compliant: Yes
U4 = CMP-04918-000581-1: IC REG BUCK ADJ 300MA TSOT23-6; Max Input Voltage: 42V; Max Output Voltage: 34V; Min Input Voltage: 4.5V; Min Output Voltage: 765mV; Number of Outputs: 1; Output Configuration: Positive; Output Type: Adjustable; Quiescent Current: 1.3mA; Switching Frequency: 1.25MHz; Synchronous Rectifier: No; Case/Package: SOT-23-6; Component Kind: Standard; Contact Plating: Tin; Lead Free: Lead Free; Library Name: Altium Content Vault; Max Operating Temperature: 125°C; Max Output Current: 300mA; Min Operating Temperature: -40°C; Mount: Surface Mount; Number of Pins: 6; Output Current: 300mA; Output Voltage: 34V; Package Quantity: 3000; Packaging: Tape and Reel; Radiation Hardening: No; RoHS Compliant: Yes; Series: SIMPLE SWITCHER®
U5 = TPS62933DRLR: IC REG BUCK ADJ 3A SOT583 Buck Switching Regulator IC Positive Adjustable 0.8V 1 Output 3A SOT-583; Manufacturer: Texas Instruments; Manufacturer Lifecycle Status: ACTIVE; Category: Voltage Regulators - Switching; Component Kind: Standard; ComponentLink1Description: https://sigma.octopart.com:443/184160452/technical_drawing/Texas-Instruments-TPS62933DRLR.pdf?src-supplier=Texas+Instruments; ComponentLink1URL: https://sigma.octopart.com:443/184160452/technical_drawing/Texas-Instruments-TPS62933DRLR.pdf?src-supplier=Texas+Instruments; ComponentLink2Description: https://octopart.com:443/opatz8j6/c1?t=FSWM8ty25CL_edLbRMdS2yrNRlbqa36hsZSNbB6qb7j2H_hUpJiJPoW4O-eV4AaSPyaz-K7aoEx2tKFLRofFqcYT3z7hMt5dnCbb-yGBGzHp3c2chbjkKS4-NESFIWq25U8__VtRD8TQ798tGZjcXZVF77sVGX00VsNi1yZeh1Xti365CMHglCt2eu7y7yIJnDjfZ1k1j8ZSL91-RMNIYXWK0qDiAHHSX9ptTuiwOdL9aShQ2dztZ5w88MiszS-cFS8N9bojYlsvPSaCiQ4Trhg3MSptcxYWX7fDNuYhg-tQaTbUOqEMDDVVzHS2EQl6D94B-7GID1nyS-uAjrkT3cRbo0P9CKHqfsfsBxRzkZaqVLgaz5oh9Ekq5vFa8h2TvdDHNjZt8A&src-supplier=Texas+Instruments; ComponentLink2URL: https://octopart.com:443/opatz8j6/c1?t=FSWM8ty25CL_edLbRMdS2yrNRlbqa36hsZSNbB6qb7j2H_hUpJiJPoW4O-eV4AaSPyaz-K7aoEx2tKFLRofFqcYT3z7hMt5dnCbb-yGBGzHp3c2chbjkKS4-NESFIWq25U8__VtRD8TQ798tGZjcXZVF77sVGX00VsNi1yZeh1Xti365CMHglCt2eu7y7yIJnDjfZ1k1j8ZSL91-RMNIYXWK0qDiAHHSX9ptTuiwOdL9aShQ2dztZ5w88MiszS-cFS8N9bojYlsvPSaCiQ4Trhg3MSptcxYWX7fDNuYhg-tQaTbUOqEMDDVVzHS2EQl6D94B-7GID1nyS-uAjrkT3cRbo0P9CKHqfsfsBxRzkZaqVLgaz5oh9Ekq5vFa8h2TvdDHNjZt8A&src-supplier=Texas+Instruments; ComponentLink3Description: https://sigma.octopart.com:443/182665545/technical_drawing/Texas-Instruments-TPS62933DRLR.pdf?src-supplier=Texas+Instruments; ComponentLink3URL: https://sigma.octopart.com:443/182665545/technical_drawing/Texas-Instruments-TPS62933DRLR.pdf?src-supplier=Texas+Instruments; ComponentLink4Description: https://sigma.octopart.com:443/158891806/technical_drawing/Texas-Instruments-TPS62933DRLR.pdf?src-supplier=Texas+Instruments; ComponentLink4URL: https://sigma.octopart.com:443/158891806/technical_drawing/Texas-Instruments-TPS62933DRLR.pdf?src-supplier=Texas+Instruments; ComponentLink5Description: https://sigma.octopart.com:443/157894082/technical_drawing/Texas-Instruments-TPS62933DRLR.pdf?src-supplier=Texas+Instruments; ComponentLink5URL: https://sigma.octopart.com:443/157894082/technical_drawing/Texas-Instruments-TPS62933DRLR.pdf?src-supplier=Texas+Instruments; ComponentLink6Description: http://sigma.octopart.com:80/conflict_mineral_statement/Texas-Instruments-company-63.pdf?src-supplier=Compliance+Statements; ComponentLink6URL: http://sigma.octopart.com:80/conflict_mineral_statement/Texas-Instruments-company-63.pdf?src-supplier=Compliance+Statements; ComponentLink7Description: http://sigma.octopart.com:80/reach_statement/Texas-Instruments-company-64.pdf?src-supplier=Compliance+Statements; ComponentLink7URL: http://sigma.octopart.com:80/reach_statement/Texas-Instruments-company-64.pdf?src-supplier=Compliance+Statements; Height: 0m; Length: 2.1mm; Library Name: Schlib2.SchLib; Lifecycle Status: Production; Manufacturer Part Number: TPS62933DRLR; Pins: 8; Pricing: 1=1.26, 10=0.917, 25=0.8304, 100=0.735, 250=0.68944, 500=0.662, 1000=0.6394 (USD); RoHS: Compliant; Stock: 26673; Supplier: DigiKey; Supplier Part Number: 296-TPS62933DRLRCT-ND; Thickness: 550µm; Topology: Buck; Width: 1.2mm
U7 = CMP-0063-01055-2: 2MHz, 600mA Step-Down DC-DC Converter, 5-pin SOT-23, Pb-Free; Manufacturer: National Semiconductor; CompType: MiscPower; PackageTopMarking: SNNB*XTT; PowerWise: Y; Component Kind: Standard; ComponentLink1Description: LM3674 Datasheet; ComponentLink1URL: http://www.national.com/ds/LM/LM3674.pdf; ComponentLink2Description: LM3674 Web Page; ComponentLink2URL: http://www.national.com/pf/LM/LM3674.html; ComponentLink3Description: MF05A Package Page; ComponentLink3URL: http://www.national.com/packaging/folders/mf05a.html; DatasheetVersion: Sep-2006; Library Name: Altium Content Vault; PackageDescription: Molded SOT-23, .115 x .063 x .040 in Body, 5 Lead, .0375 in Pitch; PackageReference: MF05A; PackageVersion: revD, Aug-2008; PinCount: 5; RevisionNote: Component URLs added; RoHS: Y
XTAL1 = HCM494000000ABJT: Description; Manufacturer_Name: Citizen; Component Kind: Standard; Library Name: HCM494000000ABJT.SchLib; Manufacturer_Part_Number: HCM494000000ABJT; Copyright: Copyright (C) 2026 Ultra Librarian. All rights reserved.
J3 = MEC6-130-02-L-D-RA1: Availability: Unavailable; Component Kind: Standard; Library Name: Schlib2.SchLib; MP: MEC6-130-02-L-D-RA1; Package: None; Price: None
